# Altium schematic sheet connect.SchDoc
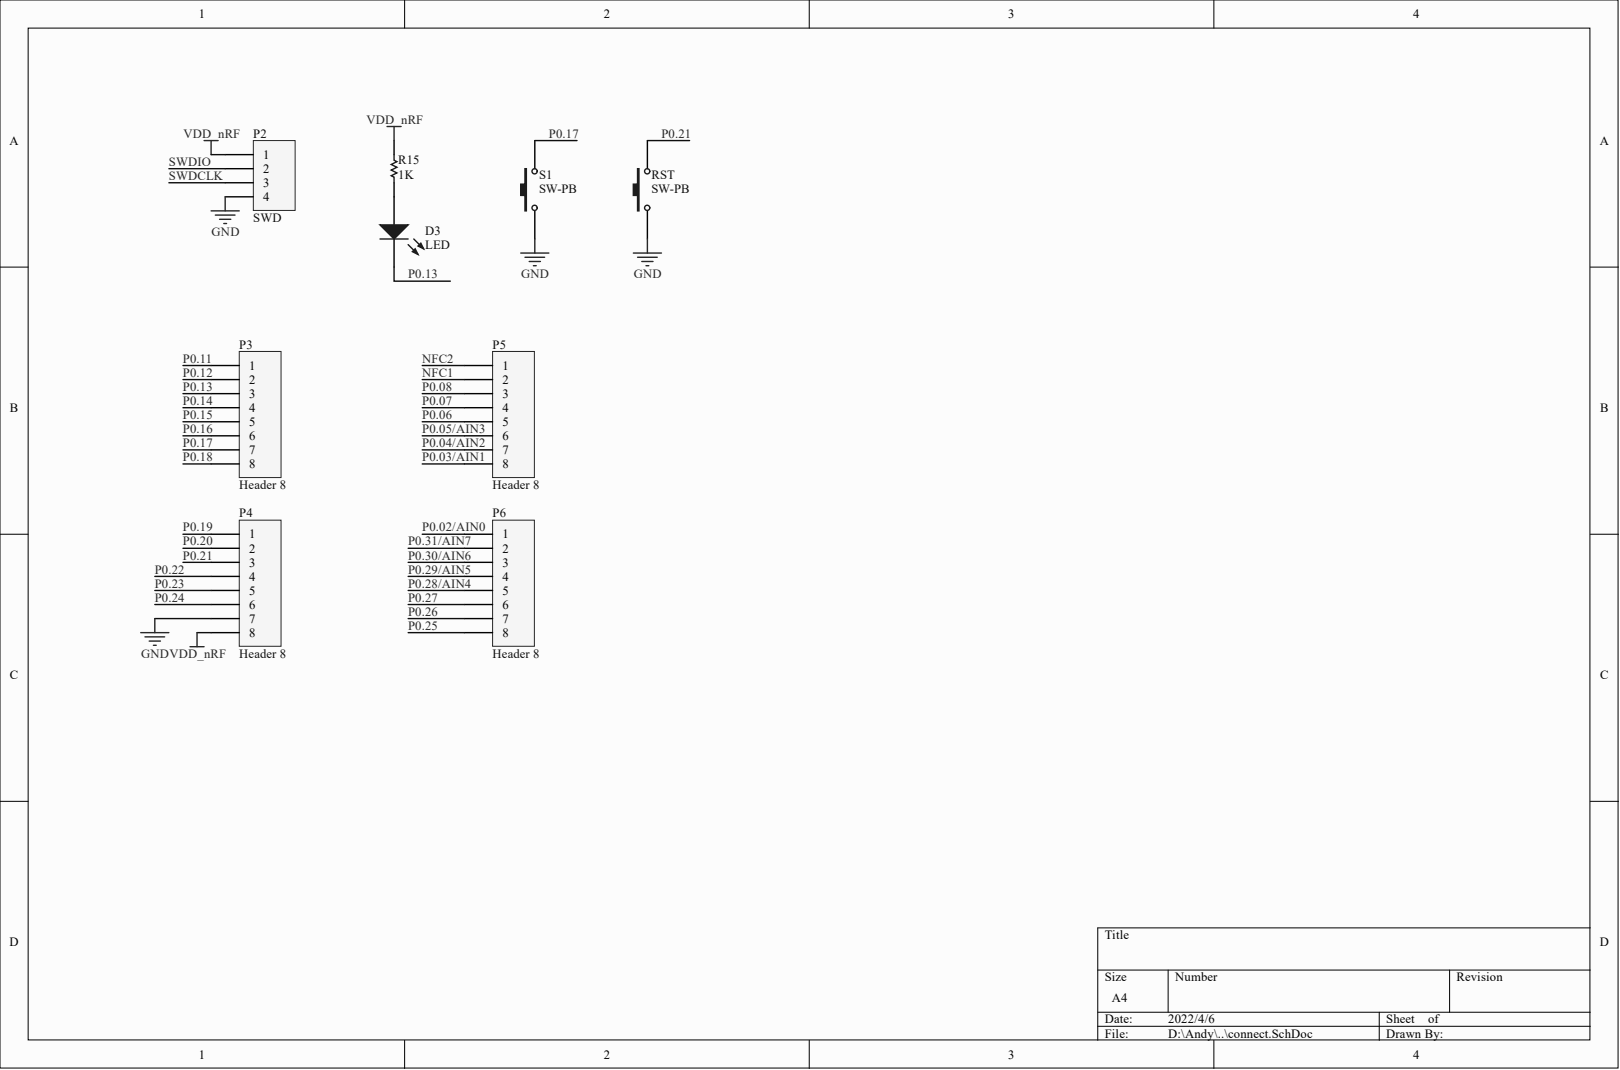
[diagram p0: connect.SchDoc]

## Parts
D3  LED  [0603]
P2  SWD  [HDR1X4]
P3  Header 8  [HDR1X8]
P4  Header 8  [HDR1X8]
P5  Header 8  [HDR1X8]
P6  Header 8  [HDR1X8]
R15  value 1K  [R0603-M]  (hidden comment: Resistors SMD)
RST  SW-PB  [SWITCH_SMD_4x3_DHT-1185]
S1  SW-PB  [SWITCH_SMD_4x3_DHT-1185]

## Nets
GND: P2.4 P4.7 RST.1 S1.1
VDD_NRF: P2.1 P4.8 R15.1
NFC1: P5.2
NFC2: P5.1
P0.02/AIN0: P6.1
P0.03/AIN1: P5.8
P0.04/AIN2: P5.7
P0.05/AIN3: P5.6
P0.06: P5.5
P0.07: P5.4
P0.08: P5.3
P0.11: P3.1
P0.12: P3.2
P0.13: D3.2 P3.3
P0.14: P3.4
P0.15: P3.5
P0.16: P3.6
P0.17: P3.7 S1.2
P0.18: P3.8
P0.19: P4.1
P0.20: P4.2
P0.21: P4.3 RST.2
P0.22: P4.4
P0.23: P4.5
P0.24: P4.6
P0.25: P6.8
P0.26: P6.7
P0.27: P6.6
P0.28/AIN4: P6.5
P0.29/AIN5: P6.4
P0.30/AIN6: P6.3
P0.31/AIN7: P6.2
SWDCLK: P2.3
SWDIO: P2.2
NetD3_1: D3.1 R15.2

## Library data of the parts
D3 = LED: Typical INFRARED GaAs LED; Component Kind: Standard; LatestRevisionDate: 08-Jul-2005; LatestRevisionNote: Mech Layer 1 removed.; Library Name: Mylibrary.IntLib; PackageDescription: LED; 2 Leads; PackageReference: LED-0; Published: 8-Jun-2000; Simulation: LED0; Publisher: Altium Limited
P2 = Header 4: Header, 4-Pin; Component Kind: Standard; LatestRevisionDate: 17-Jul-2002; LatestRevisionNote: Re-released for DXP Platform.; Library Name: Miscellaneous Connectors.IntLib; Signal Integrity: Connector; Publisher: Altium Limited
P3-P6 = Header 8: Header, 8-Pin; Component Kind: Standard; LatestRevisionDate: 17-Jul-2002; LatestRevisionNote: Re-released for DXP Platform.; Library Name: Miscellaneous Connectors.IntLib; Signal Integrity: Connector; Publisher: Altium Limited
R15 = Resistors SMD: Resistor; Component Kind: Standard; Library Name: Mylibrary.IntLib; Signal Integrity: Res; Simulation: RESISTOR
RST S1 = SW-PB: Switch; Component Kind: Standard; LatestRevisionDate: 17-Jul-2002; LatestRevisionNote: Re-released for DXP Platform.; Library Name: Mylibrary.IntLib; PackageDescription: Switch; 2 Leads; PackageReference: SPST-2; Published: 24-Mar-1999; Publisher: Altium Limited
